# Altium schematic sheet MainBoard_Light.SchDoc
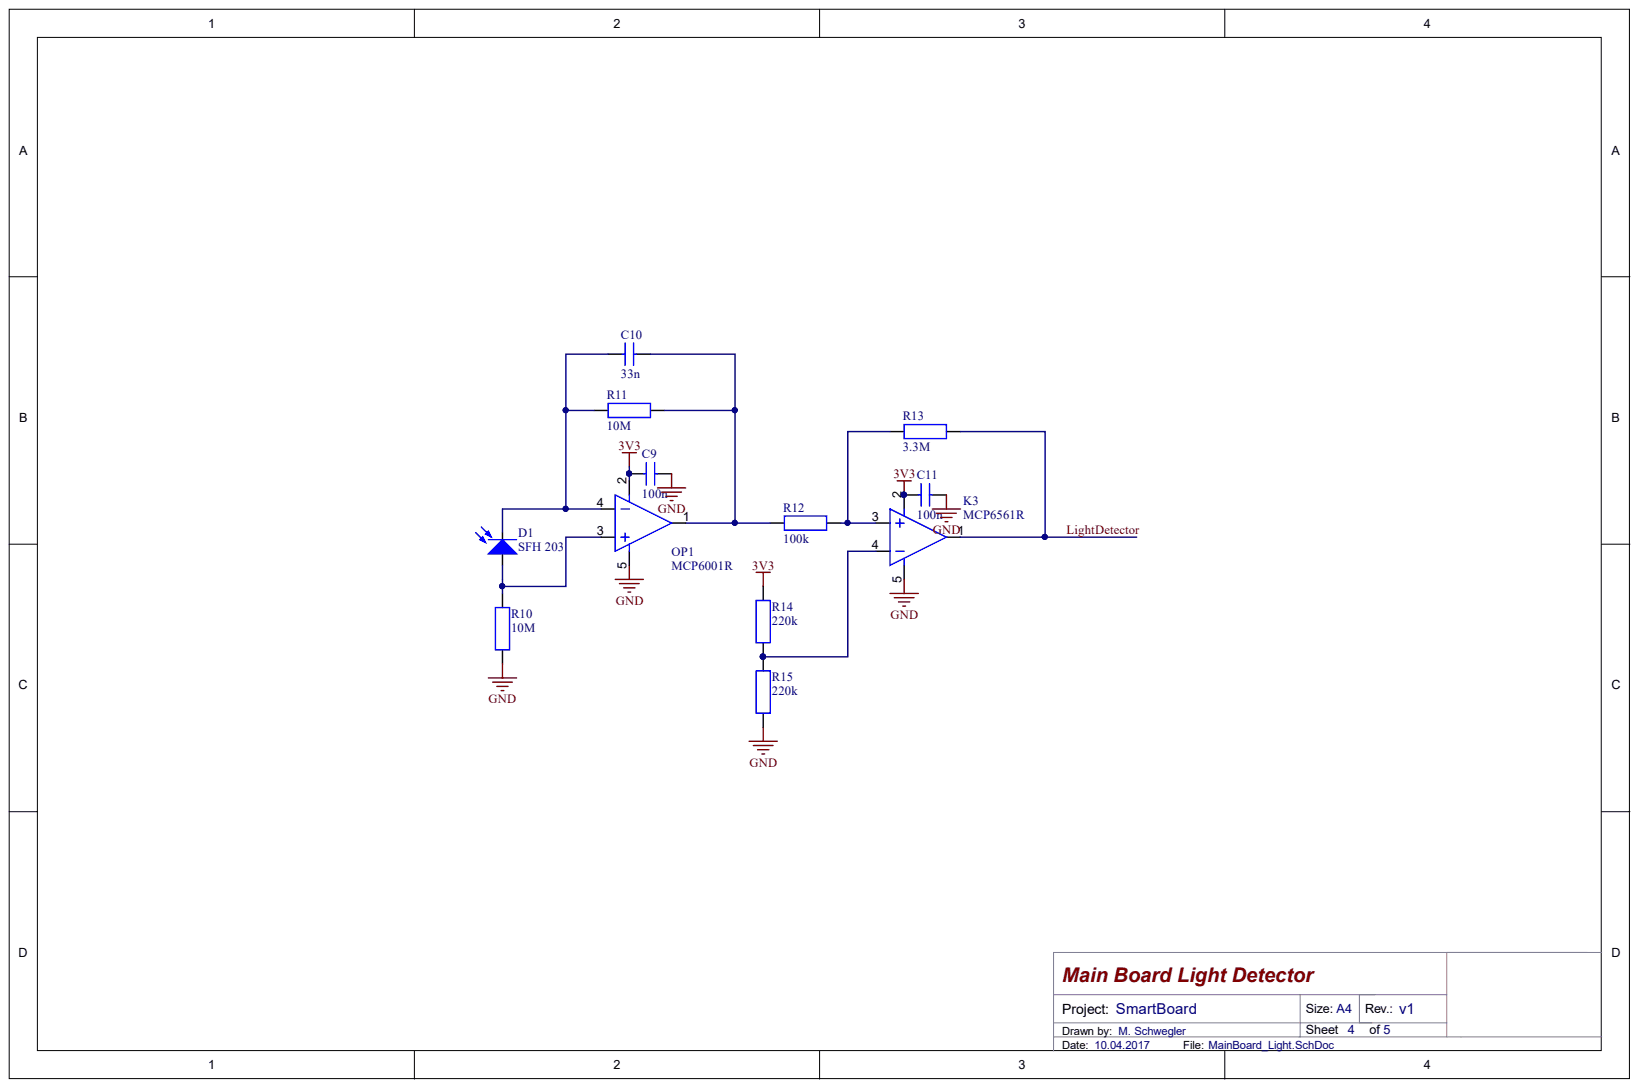
[diagram p0: MainBoard_Light.SchDoc]

## Parts
C9  100n  [0805_C]
C10  33n  [0805_C]
C11  100n  [0805_C]
D1  SFH 203  [LED_THT_5mm_RM_2.54mm]
K3  MCP6561R  [SOT23-5]
OP1  MCP6001R  [SOT23-5]
R10  10M  [0805_R]
R11  10M  [0805_R]
R12  100k  [0805_R]
R13  3.3M  [0805_R]
R14  220k  [0805_R]
R15  220k  [0805_R]

## Nets
3V3: C9.1 C11.1 K3.2 OP1.2 R14.1
GND: C9.2 C11.2 K3.5 OP1.5 R10.2 R15.2
LIGHTDETECTOR: K3.1 R13.1
NetC10_1: C10.1 D1.2 OP1.4 R11.2
NetC10_2: C10.2 OP1.1 R11.1 R12.2
NetD1_1: D1.1 OP1.3 R10.1
NetK3_3: K3.3 R12.1 R13.2
NetK3_4: K3.4 R14.2 R15.1

## Library data of the parts
C9-C11 = C?: Normaler Kondensator; Component Kind: Standard; Library Name: MainBoard.SCHLIB
D1 = Photo_Diode_1A_2K: Component Kind: Standard; Description: Photo_Diode_1A_2K; Library Name: Sch_Lib.SchLib
K3 OP1 = OPAMP: Component Kind: Standard; Library Name: MainBoard.SCHLIB
R10-R15 = R?: Normaler Widerstand; Component Kind: Standard; Library Name: MainBoard.SCHLIB
